# Altium schematic sheet PWR&Interface.SchDoc
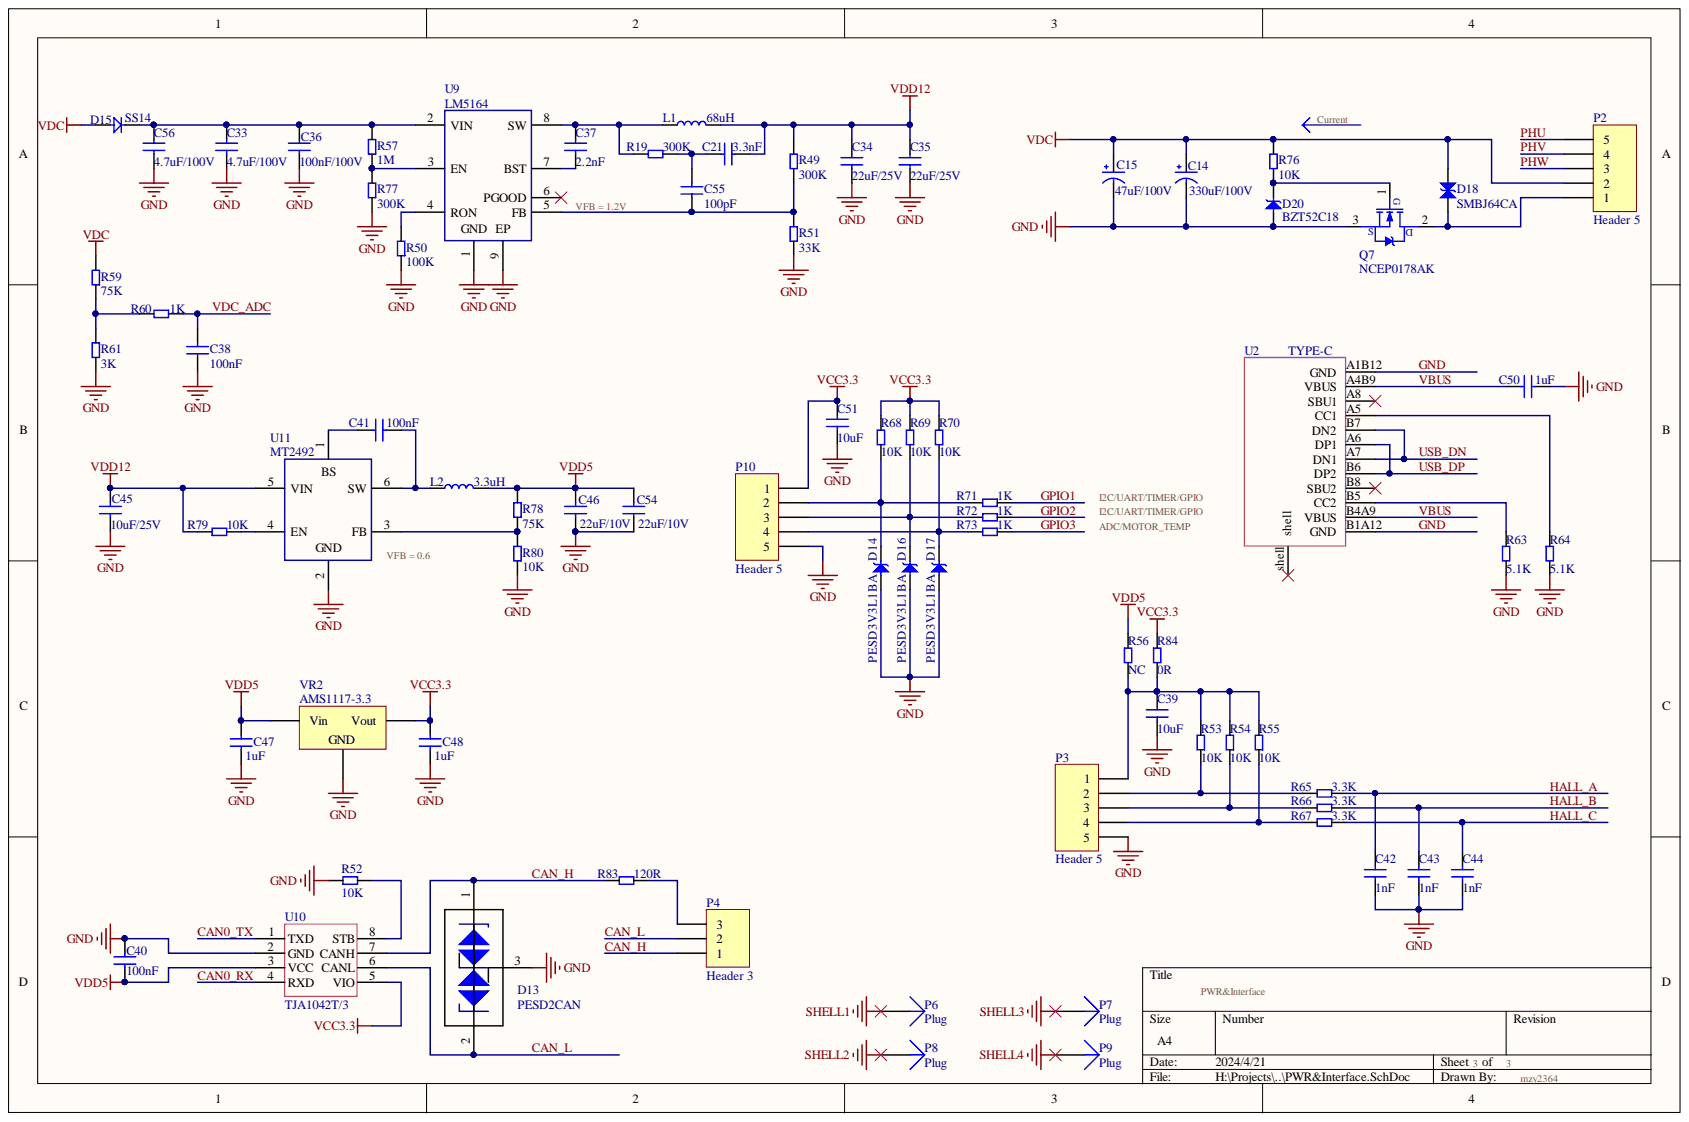
[diagram p0: PWR&Interface.SchDoc]

## Parts
C14  330uF/100V  [CAP 5.0*12.5*25-H_1]
C15  47uF/100V  [CAP 5.0*10*12.5-H]
C21  3.3nF  [C0603]
C33  4.7uF/100V  [C1210]
C34  22uF/25V  [C1206]
C35  22uF/25V  [C1206]
C36  100nF/100V  [C0805]
C37  2.2nF  [C0603]
C38  100nF  [C0603]
C39  10uF  [C0603]
C40  100nF  [C0603]
C41  100nF  [C0603]
C42  1nF  [C0603]
C43  1nF  [C0603]
C44  1nF  [C0603]
C45  10uF/25V  [C0805]
C46  22uF/10V  [C0603]
C47  1uF  [C0603]
C48  1uF  [C0603]
C50  1uF  [C0603]
C51  10uF  [C0603]
C54  22uF/10V  [C0603]
C55  100pF  [C0603]
C56  4.7uF/100V  [C1210]
D13  PESD2CAN  [SOT23]
D14  PESD3V3L1BA  [SOD323]
D15  SS14  [SMA]
D16  PESD3V3L1BA  [SOD323]
D17  PESD3V3L1BA  [SOD323]
D18  SMBJ64CA  [SMB]
D20  BZT52C18  [SOD123]
L1  68uH  [L SMD 0603]
L2  3.3uH  [L_SMNR4030]
P2  Header 5  [KF2EDGK5.08-WI-5P_1]
P3  Header 5  [KF2EDG3.81-WI-5P_1]
P4  Header 3  [KF2EDG3.81-W-3P_1]
P6  Plug  [HOLE3.2-6]
P7  Plug  [HOLE3.2-6]
P8  Plug  [HOLE3.2-6]
P9  Plug  [HOLE3.2-6]
P10  Header 5  [KF2EDG3.81-WI-5P_1]
Q7  NCEP0178AK  [TO252]
R19  300K  [RES0603]
R49  300K  [RES0603]
R50  100K  [RES0603]
R51  33K  [RES0603]
R52  10K  [RES0603]
R53  10K  [RES0603]
R54  10K  [RES0603]
R55  10K  [RES0603]
R56  NC  [RES0603]
R57  1M  [RES0603]
R59  75K  [RES0603]
R60  1K  [RES0603]
R61  3K  [RES0603]
R63  5.1K  [RES0603]
R64  5.1K  [RES0603]
R65  3.3K  [RES0603]
R66  3.3K  [RES0603]
R67  3.3K  [RES0603]
R68  10K  [RES0603]
R69  10K  [RES0603]
R70  10K  [RES0603]
R71  1K  [RES0603]
R72  1K  [RES0603]
R73  1K  [RES0603]
R76  10K  [RES0603]
R77  300K  [RES0603]
R78  75K  [RES0603]
R79  10K  [RES0603]
R80  10K  [RES0603]
R83  120R  [RES0805]
R84  0R  [RES0603]
U2  TYPE-C  [USB-TYPE-C-601-WSC]
U9  LM5164  [SOIC-8_EP_150mil]
U10  TJA1042T/3  [SOIC8-150MIL]
U11  MT2492  [SOT23-6]
VR2  AMS1117-3.3  [LC-SOT-223]

## Nets
SHELL1: P6.1
SHELL2: P8.1
SHELL3: P7.1
SHELL4: P9.1
VCC3.3: C48.2 C51.2 P10.1 R68.2 R69.2 R70.2 R84.1 U10.5(VIO) VR2.2
VDC: C14.1 C15.1 D15.1 D18.2 P2.2 R59.2 R76.2
VDD5: C40.1 C46.2 C47.2 C54.2 L2.1 R56.1 R78.2 U10.3(VCC) VR2.3
VDD12: C21.1 C34.2 C35.2 C45.2 L1.1 R49.2 R79.1 U11.5(VIN)
CAN0_RX: U10.4(RXD)
CAN0_TX: U10.1(TXD)
CAN_H: D13.1 P4.1 R83.2 U10.7(CANH)
CAN_L: D13.2 P4.2 U10.6(CANL)
GND: C14.2 C15.2 C33.1 C34.1 C35.1 C36.1 C38.1 C39.1 C40.2 C42.1 C43.1 C44.1 C45.1 C46.1 C47.1 C48.1 C50.1 C51.1 C54.1 C56.1 D13.3 D14.1 D16.1 D17.1 D20.1 P3.5 P10.5 Q7.3 R50.1 R51.1 R52.1 R61.1 R63.2 R64.2 R77.1 R80.1 U2.A1B12(GND) U2.B1A12(GND) U9.1 U9.9(EP) U10.2(GND) U11.2 VR2.1
GPIO1: R71.1
GPIO2: R72.1
GPIO3: R73.1
HALL_A: C42.2 R65.1
HALL_B: C43.2 R66.1
HALL_C: C44.2 R67.1
PHU: P2.5
PHV: P2.4
PHW: P2.3
USB_DN: U2.A7(DN1) U2.B7(DN2)
USB_DP: U2.A6(DP1) U2.B6(DP2)
VBUS: C50.2 U2.A4B9(VBUS) U2.B4A9(VBUS)
VDC_ADC: C38.2 R60.1
NetC21_2: C21.2 C55.1 R19.1
NetC33_2: C33.2 C36.2 C56.2 D15.2 R57.2 U9.2(VIN)
NetC37_1: C37.1 U9.7(BST)
NetC37_2: C37.2 L1.2 R19.2 U9.8(SW)
NetC39_2: C39.2 P3.1 R53.2 R54.2 R55.2 R56.2 R84.2
NetC41_1: C41.1 L2.2 U11.6(SW)
NetC41_2: C41.2 U11.1(BS)
NetC55_2: C55.2 R49.1 R51.2 U9.5(FB)
NetD14_2: D14.2 P10.2 R68.1 R71.2
NetD16_2: D16.2 P10.3 R69.1 R72.2
NetD17_2: D17.2 P10.4 R70.1 R73.2
NetD18_1: D18.1 P2.1 Q7.2
NetD20_2: D20.2 Q7.1 R76.1
NetP3_2: P3.2 R53.1 R65.2
NetP3_3: P3.3 R54.1 R66.2
NetP3_4: P3.4 R55.1 R67.2
NetP4_3: P4.3 R83.1
NetR50_2: R50.2 U9.4(RON)
NetR52_2: R52.2 U10.8(STB)
NetR57_1: R57.1 R77.2 U9.3(EN)
NetR59_1: R59.1 R60.2 R61.2
NetR63_1: R63.1 U2.B5(CC2)
NetR64_1: R64.1 U2.A5(CC1)
NetR78_1: R78.1 R80.2 U11.3(FB)
NetR79_2: R79.2 U11.4(EN)

Not connected: U2 A8(SBU1) B8(SBU2) shell; U9 6(PGOOD)

## Library data of the parts
C14 C15 = C POL: Component Kind: Standard; Library Name: mzy2364.SchLib
C21 C33-C38 C40 C41 C45-C48 C50 C54-C56 = CAP: Component Kind: Standard; Library Name: mzy2364.SchLib
C39 C42-C44 C51 = CAP: Material: X7R; Precision: 10%; Voltage: 50V; Component Kind: Standard; Library Name: mzy2364.SchLib
D14 D16 D17 D20 = D TVS: Component Kind: Standard; Library Name: mzy2364.SchLib
D15 = D: Component Kind: Standard; Library Name: mzy2364.SchLib
D18 = D TVS-Double: 双向tvs二极管; Component Kind: Standard; Library Name: mzy2364.SchLib
L1 L2 = L: Component Kind: Standard; Library Name: mzy2364.SchLib
P2 P3 P10 = Header 5: Header, 5-Pin; Component Kind: Standard; LatestRevisionDate: 17-Jul-2002; LatestRevisionNote: Re-released for DXP Platform.; Library Name: Miscellaneous Connectors.IntLib; Signal Integrity: Connector; Publisher: Altium Limited
P4 = Header 3: Header, 3-Pin; Component Kind: Standard; LatestRevisionDate: 17-Jul-2002; LatestRevisionNote: Re-released for DXP Platform.; Library Name: Miscellaneous Connectors.IntLib; Signal Integrity: Connector; Publisher: Altium Limited
Q7 = NMOS-TO252: Component Kind: Standard; Library Name: mzy2364.SchLib
R19 R49-R57 R59-R61 R63-R73 R76-R80 R83 R84 = RES: Component Kind: Standard; Library Name: mzy2364.SchLib
U2 = USB TYPE-C: TYPE-C 16pin; Component Kind: Standard; Library Name: mzy2364.SchLib
U9 = LM5164: 具有超低 IQ 的 LM5164 100V 输入、 1A 同步降压直流/直流转换器; Component Kind: Standard; Library Name: mzy2364.SchLib
U10 = TJA1042T/3: CAN收发器; Component Kind: Standard; Library Name: mzy2364.SchLib
U11 = MT2492: 4.5V-16V 2A DC-DC芯片; Component Kind: Standard; Library Name: mzy2364.SchLib
VR2 = SOT-223 AMS1117-3.3: 低压差线性稳压(LDO); Component Kind: Standard; ComponentLink1Description: 供应商链接; ComponentLink1URL: http://www.szlcsc.com/product/details_6652.html; Library Name: Miscellaneous Devices LC.IntLib; Package: SOT-223; Supplier: LC; SuppliersPartNumber: C6186
D13 = PESD2CAN: Component Kind: Standard; Library Name: EDC17_5644A.SCHLIB
P6-P9 = Plug: Component Kind: Standard; Description: Plug; LatestRevisionDate: 17-Jul-2002; LatestRevisionNote: Re-released for DXP Platform.; Library Name: Miscellaneous Connectors.IntLib; Signal Integrity: Connector; Publisher: Altium Limited
